# Altium schematic sheet Display.SchDoc(Display)
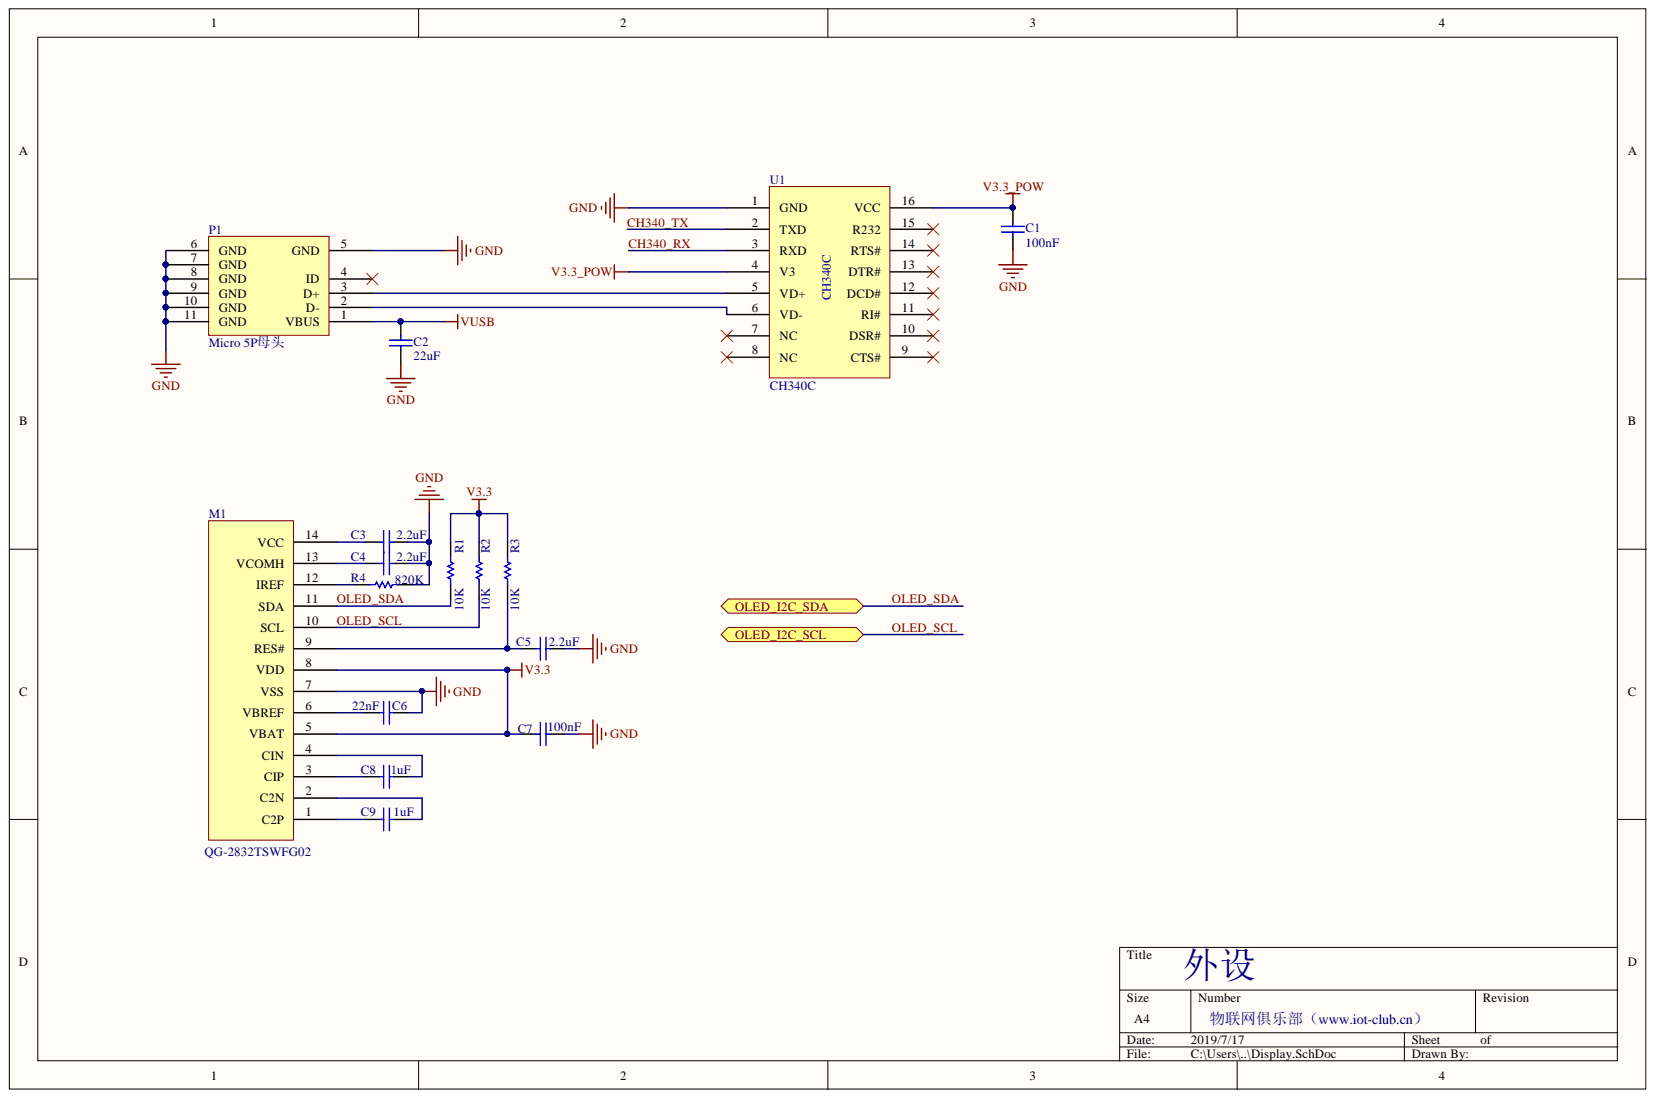
[diagram p0: Display.SchDoc]

## Parts
C1  100nF  [C0603]
C2  22uF  [LC-1206_C]
C3  2.2uF  [C0603]
C4  2.2uF  [C0603]
C5  2.2uF  [C0603]
C6  22nF  [C0603]
C7  100nF  [C0603]
C8  1uF  [C0603]
C9  1uF  [C0603]
M1  QG-2832TSWFG02  [QG-2832TSWFG02]  (no BOM)
P1  Micro 5P母头  [USB_Micro]
R1  10K  [R0603]
R2  10K  [R0603]
R3  10K  [R0603]
R4  820K  [R0603]
U1  CH340C  [SOP16_N]

## Ports
OLED_I2C_SCL, OLED_I2C_SDA

## Nets
GND: C1.1 C2.1 C3.2 C4.2 C5.2 C6.2 C7.1 M1.7(VSS) P1.5(GND) P1.6(GND) P1.7(GND) P1.8(GND) P1.9(GND) P1.10(GND) P1.11(GND) R4.2 U1.1(GND)
V3.3: C7.2 M1.5(VBAT) M1.8(VDD) R1.2 R2.2 R3.2
V3.3_POW: C1.2 U1.4(V3) U1.16(VCC)
VUSB: C2.2 P1.1(VBUS)
CH340_RX: U1.3(RXD)
CH340_TX: U1.2(TXD)
OLED_SCL: M1.10(SCL) R2.1 ; port OLED_I2C_SCL
OLED_SDA: M1.11(SDA) R1.1 ; port OLED_I2C_SDA
NetC3_1: C3.1 M1.14(VCC)
NetC4_1: C4.1 M1.13(VCOMH)
NetC5_1: C5.1 M1.9(RES#) R3.1
NetC6_1: C6.1 M1.6(VBREF)
NetC8_1: C8.1 M1.4(CIN)
NetC8_2: C8.2 M1.3(CIP)
NetC9_1: C9.1 M1.2(C2N)
NetC9_2: C9.2 M1.1(C2P)
NetM1_12: M1.12(IREF) R4.1
NetP1_2: P1.2(D-) U1.6(VD-)
NetP1_3: P1.3(D+) U1.5(VD+)

Not connected: P1 4(ID); U1 7(NC) 8(NC) 9(CTS#) 10(DSR#) 11(RI#) 12(DCD#) 13(DTR#) 14(RTS#) 15(R232)

## Library data of the parts
C1-C9 = CAP: Capacitor; Library Name: Devices.IntLib; Component Kind: Standard
M1 = OLED_0.91: Library Name: Custom.IntLib
P1 = USB_Micro: Library Name: Custom.IntLib; Component Kind: Standard
R1-R4 = Res1: Resistor; Value: 1K; Simulation: RESISTOR; Signal Integrity: Res; Published: 8-Jun-2000; PackageReference: AXIAL-0.3; PackageDescription: Axial Device, Thru-Hole; 2 Leads; 0.3 in Pin Spacing; Library Name: Devices.IntLib; LatestRevisionNote: Re-released for DXP Platform.; LatestRevisionDate: 17-Jul-2002; Component Kind: Standard; Publisher: Altium Limited
U1 = CH340C: Library Name: IC.SchLib; Component Kind: Standard
